# Altium schematic sheet MSXIV_DC-DC_Converter.SchDoc
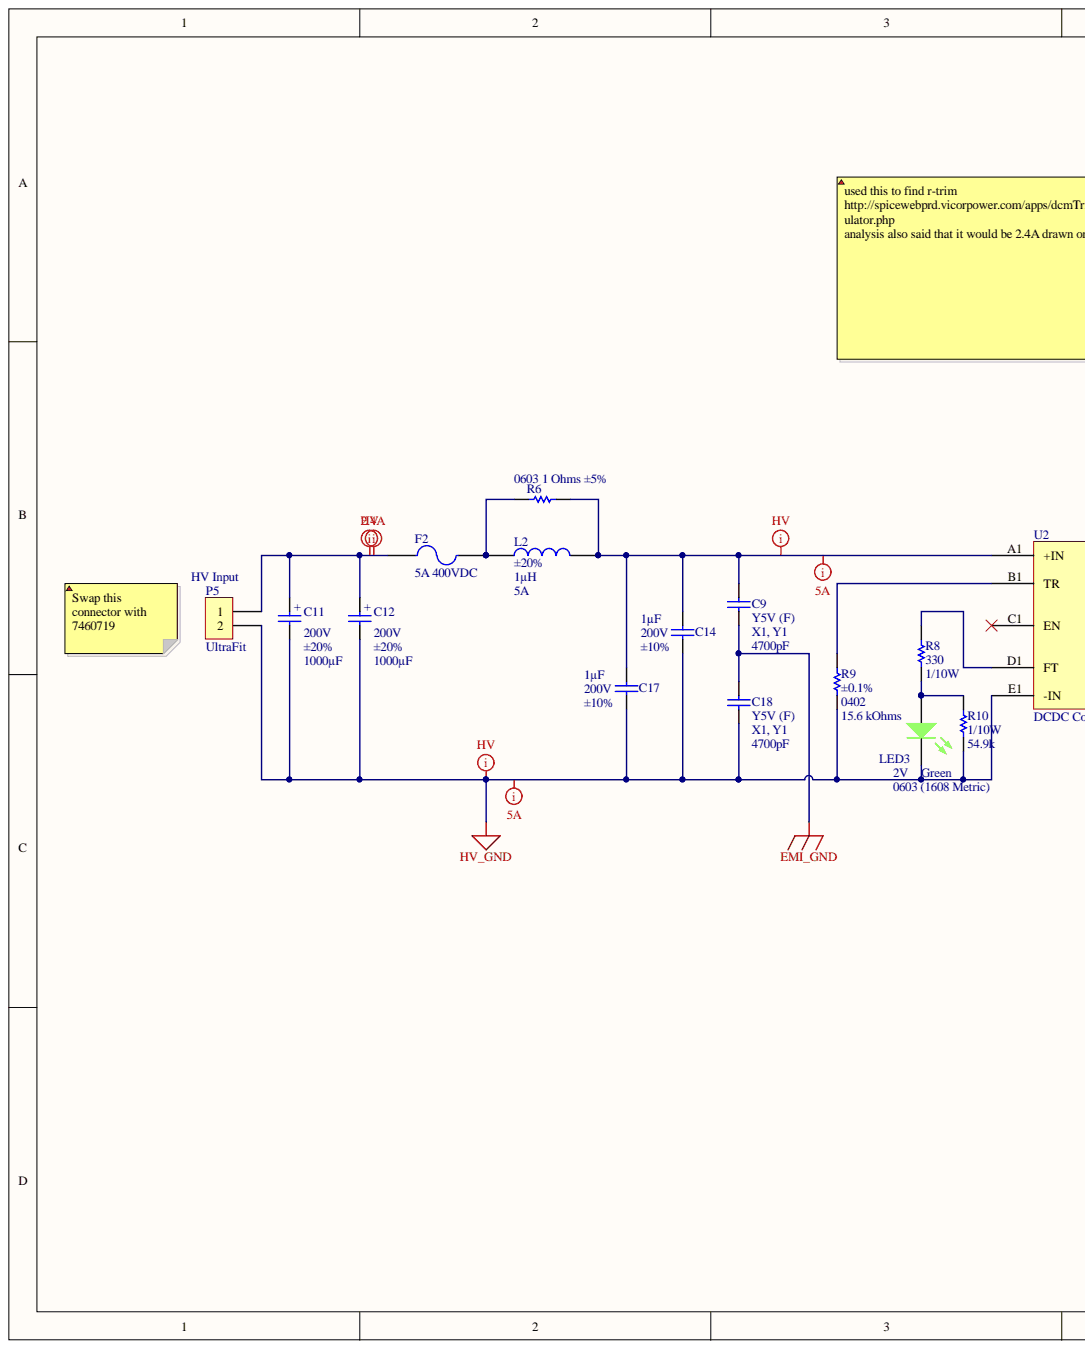
[diagram p0: MSXIV_DC-DC_Converter.SchDoc, left]
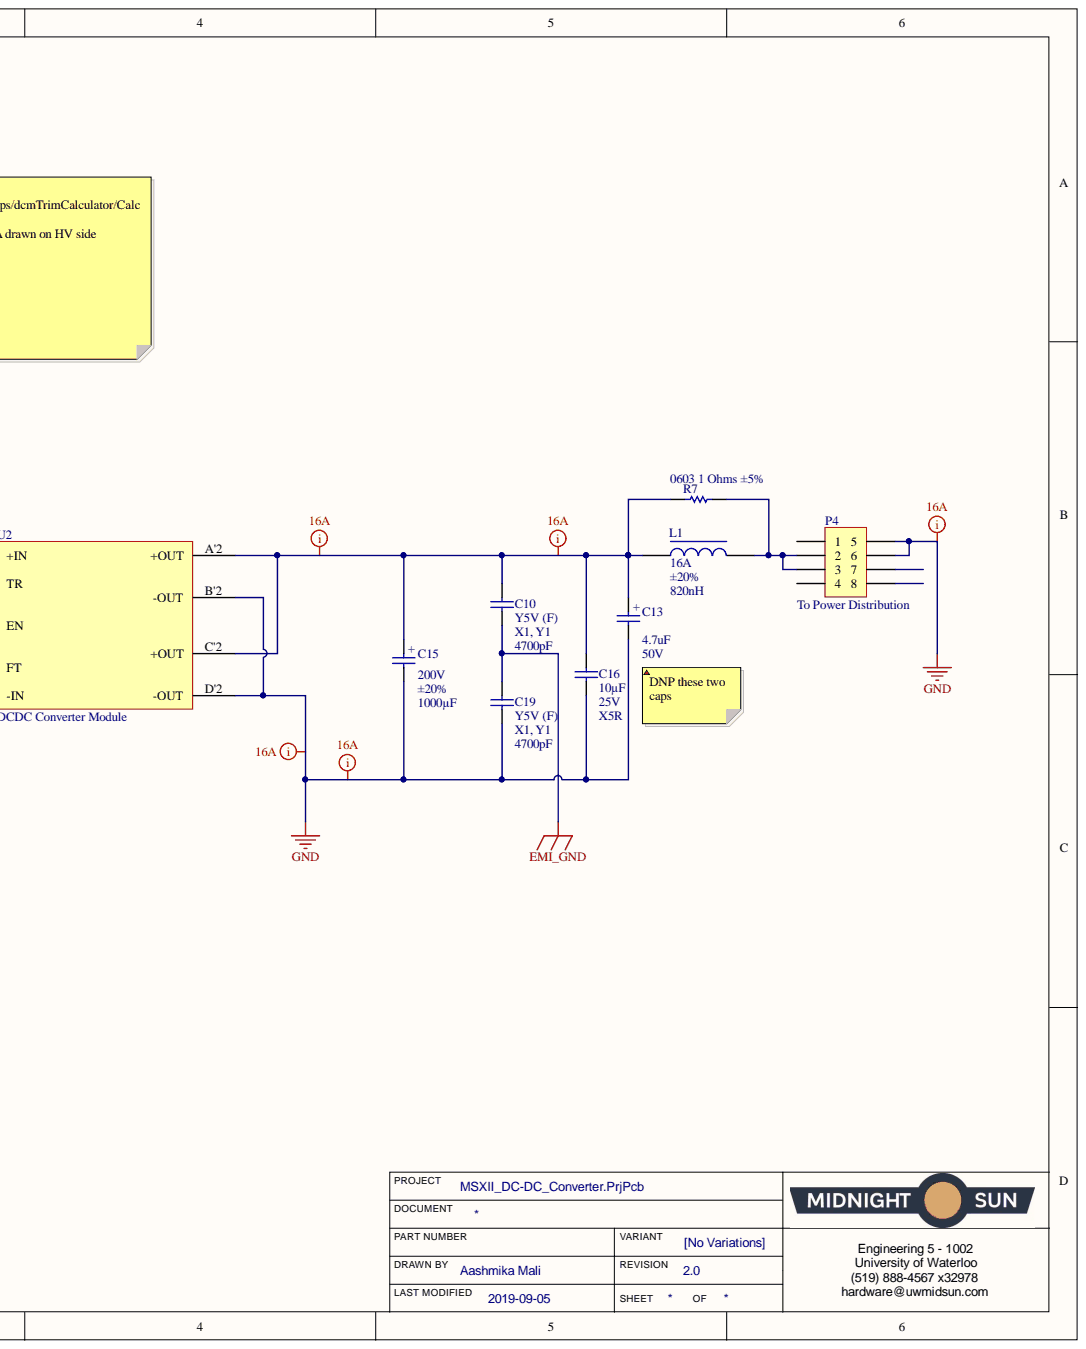
[diagram p1: MSXIV_DC-DC_Converter.SchDoc, right]

## Parts
C9  value 4700pF  Ratings: X1, Y1  Temperature Coefficient: Y5V (F)  [CAP 4700PF 400VAC Y5V RADIAL]  (hidden comment: CAP CER 4700PF 400VAC Y5V RADIAL)
C10  value 4700pF  Ratings: X1, Y1  Temperature Coefficient: Y5V (F)  [CAP 4700PF 400VAC Y5V RADIAL]  (hidden comment: CAP CER 4700PF 400VAC Y5V RADIAL)
C11  Capacitance: 1000µF  Tolerance: ±20%  Voltage - Rated: 200V  (hidden comment: CAP ALUM 1000UF 20% 200V SNAP)
C12  Capacitance: 1000µF  Tolerance: ±20%  Voltage - Rated: 200V  (hidden comment: CAP ALUM 1000UF 20% 200V SNAP)
C13  Capacitance: 4.7uF  Voltage Rating: 50V  [CAP ALUM 6.3MM RADIAL SMD]  (hidden comment: EEE-1HA4R7NP)
C14  Capacitance: 1µF  Tolerance: ±10%  Voltage - Rated: 200V  (hidden comment: CAP CER 1UF 200V X7R 2220)
C15  Capacitance: 1000µF  Tolerance: ±20%  Voltage - Rated: 200V  (hidden comment: CAP ALUM 1000UF 20% 200V SNAP)
C16  Capacitance: 10µF  Temperature Coefficient: X5R  Voltage: 25V  [CAP, 0603]  (hidden comment: CAP CER 10uF 25V 20% X5R 0603)
C17  Capacitance: 1µF  Tolerance: ±10%  Voltage - Rated: 200V  (hidden comment: CAP CER 1UF 200V X7R 2220)
C18  value 4700pF  Ratings: X1, Y1  Temperature Coefficient: Y5V (F)  [CAP 4700PF 400VAC Y5V RADIAL]  (hidden comment: CAP CER 4700PF 400VAC Y5V RADIAL)
C19  value 4700pF  Ratings: X1, Y1  Temperature Coefficient: Y5V (F)  [CAP 4700PF 400VAC Y5V RADIAL]  (hidden comment: CAP CER 4700PF 400VAC Y5V RADIAL)
F2  5A 400VDC  [FUSE 5A 400VDC RADIAL]
L1  Current Rating (Amps): 16A  Tolerance: ±20%  (hidden comment: IND 820NH 16A 6 MOHM SMD)
L2  Current Rating (Amps): 5A  Inductance: 1µH  Tolerance: ±20%  (hidden comment: IND 1UH 5A 12MOHM SMD)
LED3  Color: Green  Package / Case: 0603 (1608 Metric)  Supplier Device Package: 0603  Voltage - Forward (Vf) (Typ): 2V  [LED_0603]  (hidden comment: LED GREEN CLEAR 2V 0603)
P4  CONN 8POS ULTRA-FIT 0.138"  [CONN, 8POS ULTRA-FIT]  (value not drawn)
P5  Series: UltraFit  [CONN, 2POS ULTRA-FIT]  (hidden comment: CONN 2POS ULTRA-FIT 0.138")
R6  Resistance: 1 Ohms  Supplier Device Package: 0603  Tolerance: ±5%  (hidden comment: RES 1 OHM 5% 1/10W 0603)
R7  Resistance: 1 Ohms  Supplier Device Package: 0603  Tolerance: ±5%  (hidden comment: RES 1 OHM 5% 1/10W 0603)
R8  Power: 1/10W  [RES, 0603]  (hidden comment: RES 330 OHM 1% 1/10W 0603)
R9  Supplier Device Package: 0402  Tolerance: ±0.1%  (hidden comment: RES 15.6K OHM 0.1% 1/10W 0402)
R10  Power (Watts): 1/10W  Resistance (Ohms): 54.9k  [RES, 0603]  (hidden comment: RES 54.9K OHM 1% 1/10W 0603)
U2  Type: DCDC Converter Module  (hidden comment: DCDC VICOR CONVERTER 15V 240W - DCM3623TA5N17B4T70)

## Nets
EMI_GND: C9.2 C10.2 C18.1 C19.1
GND: C13.2 C15.2 C16.2 C19.2 P4.5 P4.6 U2.B'2(-OUT) U2.D'2(-OUT)
HV_GND: C11.2 C12.2 C14.2 C17.2 C18.2 LED3.2 P5.2 R9.1 R10.2 U2.E1(-IN)
NetC9_1: C9.1 C14.1 C17.1 L2.2 R6.2 U2.A1(+IN)
NetC10_1: C10.1 C13.1 C15.1 C16.1 L1.1 R7.1 U2.A'2(+OUT) U2.C'2(+OUT)
NetC11_1: C11.1 C12.1 F2.1 P5.1
NetF2_2: F2.2 L2.1 R6.1
NetL1_2: L1.2 P4.2 P4.3 R7.2
NetLED3_1: LED3.1 R8.2 R10.1
NetR8_1: R8.1 U2.D1(FT)
NetR9_2: R9.2 U2.B1(TR)

Not connected: P4 1 4 7 8; U2 C1(EN)

## Library data of the parts
C9 C10 C18 C19 = CAP CER 4700PF 400VAC Y5V RADIAL: 4700pF ±20% 400VAC Ceramic Capacitor Y5V (F) Radial, Disc; Manufacturer: KEMET; Manufacturer Part Number: C951U472MVVDBA7317; Applications: Safety; Category: Capacitors; Component Kind: Standard; ComponentLink1Description: https://search.kemet.com/component-edge/download/datasheet/C951U472MVVDBA7317.pdf; ComponentLink1URL: https://search.kemet.com/component-edge/download/datasheet/C951U472MVVDBA7317.pdf; Failure Rate: -; Features: -; Height - Seated (Max): -; Lead Spacing: 0.394" (10.00mm); Lead Style: Formed Leads - Kinked; Mounting Type: Through Hole; Operating Temperature: -40°C ~ 125°C; Package / Case: Radial, Disc; Packaging: Cut Tape (CT); Part Status: Active; Pricing 1: 1=1.12, 10=0.795, 100=0.5234, 500=0.38772 (CAD); RoHS: RoHS Compliant; Size / Dimension: 0.472" Dia (12.00mm); Stock 1: 9713; Supplier 1: Digi-Key; Supplier Part Number 1: 399-9517-1-ND; Thickness (Max): -; Tolerance: ±20%; Voltage - Rated: 400VAC; Library Name: Schematic Diagrams.SchLib
C11 C12 C15 = CAP ALUM 1000UF 20% 200V SNAP: CAP RADIAL ALUM 1000UF 20% 200V SNAP; Manufacturer: Cornell Dubilier Electronics (CDE); Manufacturer Part Number: SLPX102M200C4P3; ESR (Equivalent Series Resistance): 199mOhm @ 120Hz; Polarization: Polar; Component Kind: Standard; Mounting Type: Through Hole; Package / Case: Radial, Can - Snap-In; Supplier 1: Digi-Key; Supplier Part Number 1: 338-1557-ND; Library Name: Schematic Diagrams.SchLib
C13 = CAP ALUM 4.7UF 20% 50V SMD: 4.7µF 50V Aluminum Electrolytic Capacitors Radial, Can - SMD 2000 Hrs @ 85°C; Manufacturer 1: Panasonic; Description 1: CAPACITOR ALUM ELEC, 4.7UF, 50V, 20%, SMD; Life (Hours): 2000Hour; Ripple Current: 31mA; Tolerance: 20%; Case/Package: Radial; Component Kind: Standard; ComponentLink1Description: https://datasheet.ciiva.com/46374/aba0000ce2-46374373.pdf; ComponentLink1URL: https://datasheet.ciiva.com/46374/aba0000ce2-46374373.pdf; ComponentLink2Description: https://datasheet.ciiva.com/14600/70255710-14600343.pdf; ComponentLink2URL: https://datasheet.ciiva.com/14600/70255710-14600343.pdf; ComponentLink3Description: https://datasheet.ciiva.com/17536/aba0000ce2-17536647.pdf; ComponentLink3URL: https://datasheet.ciiva.com/17536/aba0000ce2-17536647.pdf; ComponentLink4Description: https://datasheet.ciiva.com/24027/aba0000ce2-40801-24027083.pdf; ComponentLink4URL: https://datasheet.ciiva.com/24027/aba0000ce2-40801-24027083.pdf; ComponentLink5Description: https://datasheet.ciiva.com/31414/aba0000ce2-31414684.pdf; ComponentLink5URL: https://datasheet.ciiva.com/31414/aba0000ce2-31414684.pdf; ComponentLink6Description: https://datasheet.ciiva.com/14606/70255710-14606079.pdf; ComponentLink6URL: https://datasheet.ciiva.com/14606/70255710-14606079.pdf; Diameter: 6.3mm; Height: 5.4mm; Height - Seated (Max): 0.213inch; Lead Free: Lead Free; Length: 5.5mm; Manufacturer Part Number 1: EEE-1HA4R7NP; Max Operating Temperature: 85°C; Min Operating Temperature: -40°C; Mount: Surface Mount,PCB; Package Quantity: 1; Packaging: Tape and Reel; Pricing 1: 1=0.43, 10=0.302, 100=0.1986, 500=0.1471 (USD); Radiation Hardening: No; REACH SVHC: No SVHC; Ripple Current (AC): 31mA; Stock 1: 19771; Supplier 1: Digi-Key; Supplier Part Number 1: PCE4304CT-ND; Termination: SMD/SMT; Voltage Rating (DC): 50V; Width: 650um; Library Name: Schematic Diagrams.SchLib
C14 C17 = CAP CER 1UF 200V X7R 2220: 1µF ±10% 200V Ceramic Capacitor X7R 2220 (5750 Metric); Manufacturer: Murata Electronics; Manufacturer Part Number: GRM55DR72D105KW01L; Component Kind: Standard; Mounting Type: Surface Mount, MLCC; Package / Case: 2220 (5750 Metric); Size / Dimension: 0.224" L x 0.197" W (5.70mm x 5.00mm); Supplier 1: Digi-Key; Supplier Part Number 1: 490-14683-1-ND; Temperature Coefficient: X7R; Library Name: Schematic Diagrams.SchLib
C16 = CAP CER 10uF 25V 20% X5R 0603: 10µF ±20% 25V Ceramic Capacitor X5R 0603 (1608 Metric); Manufacturer 1: Murata Electronics North America; Manufacturer Part Number 1: GRM188R61E106MA73D; Component Kind: Standard; ComponentLink1Description: http://www.murata.com/~/media/webrenewal/support/library/catalog/products/capacitor/mlcc/c02e.ashx; ComponentLink1URL: http://www.murata.com/~/media/webrenewal/support/library/catalog/products/capacitor/mlcc/c02e.ashx; Height - Seated (Max): -; Lead Spacing: -; Lead Style: -; Mounting Type: Surface Mount, MLCC; Operating Temperature: -55°C ~ 85°C; Package / Case: 0603 (1608 Metric); Packaging: Cut Tape (CT); Part Status: Active; Ratings: -; Size / Dimension: 0.063" L x 0.031" W (1.60mm x 0.80mm); Supplier 1: Digi-Key; Supplier Part Number 1: 490-7202-1-ND; Thickness (Max): 0.031" (0.80mm); Tolerance: ±20%; Library Name: Schematic Diagrams.SchLib
F2 = FUSE 5A 125VAC/400VDC RADIAL: FUSE BOARD MNT 5A 125VAC/400VDC; Manufacturer: Eaton; Manufacturer Part Number: BK/PCE-5-R; Approvals: CSA, UL; Breaking Capacity @ Rated Voltage: 10kA AC, 4.2kA DC; Fuse Type: Board Mount (Cartridge Style Excluded); Response Time: Fast; Category: Circuit Protection; Color: -; Component Kind: Standard; ComponentLink1Description: http://www.cooperindustries.com/content/dam/public/bussmann/Electronics/Resources/product-datasheets/Bus_Elx_DS_2034_PC_Tron_Series.pdf; ComponentLink1URL: http://www.cooperindustries.com/content/dam/public/bussmann/Electronics/Resources/product-datasheets/Bus_Elx_DS_2034_PC_Tron_Series.pdf; Mounting Type: Through Hole; Operating Temperature: -; Package / Case: Radial, Box; Packaging: Bulk; Part Status: Active; Pricing 1: 1=3.06, 5=2.91, 10=2.765, 50=2.2306, 100=1.8911, 250=1.8426, 500=1.55166, 1000=1.38196, 5000=1.21224 (CAD); RoHS: RoHS Compliant; Size / Dimension: 0.300" L x 0.095" W x 0.170" H (7.62mm x 2.41mm x 4.32mm); Stock 1: 0; Supplier 1: Digi-Key; Supplier Part Number 1: 283-2770-ND; Library Name: Schematic Diagrams.SchLib
L1 = IND 820NH 16A 6 MOHM SMD: 820nH Shielded Molded Inductor 16A 6mOhm Max Nonstandard; Manufacturer: ECS Inc.; Manufacturer Part Number: ECS-MPIL0630-R82MC; Component Kind: Standard; DC Resistance (DCR): 6mOhm Max; Height - Seated (Max): 0.118" (3.00mm); Inductance: 820nH; Mounting Type: Surface Mount; Package / Case: Nonstandard; Shielding: Shielded; Size / Dimension: 0.291" L x 0.260" W (7.40mm x 6.60mm); Supplier 1: Digi-Key; Supplier Part Number 1: XC2399CT-ND; Library Name: Schematic Diagrams.SchLib
L2 = IND 1UH 5A 12MOHM SMD: 1µH Semi-Shielded Wirewound Inductor 5A 12mOhm Nonstandard; Manufacturer: Bourns Inc.; Manufacturer Part Number: SRN5040TA-1R0M; Current - Saturation: 7.5A; Component Kind: Standard; DC Resistance (DCR): 12mOhm; Mounting Type: Surface Mount; Package / Case: Nonstandard; Shielding: Semi-Shielded; Supplier 1: Digi-Key; Supplier Part Number 1: SRN5040TA-1R0MCT-ND; Library Name: Schematic Diagrams.SchLib
LED3 = LED GREEN CLEAR 2V 0603: Green 572nm LED Indication - Discrete 2V 0603 (1608 Metric); Manufacturer: Wurth Electronics Inc.; Manufacturer Part Number: 150060VS75000; Current - Test: 20mA; Lens Color: Colorless; Lens Style/Size: Rectangle with Flat Top, 1.10mm x 0.70mm; Millicandela Rating: 40mcd; Viewing Angle: 140°; Wavelength - Dominant: 570nm; Category: Optoelectronics; Component Kind: Standard; ComponentLink1Description: http://katalog.we-online.de/led/datasheet/150060VS75000.pdf; ComponentLink1URL: http://katalog.we-online.de/led/datasheet/150060VS75000.pdf; Features: -; Height (Max): 0.80mm; Lens Transparency: Clear; Mounting Type: Surface Mount; Packaging: Cut Tape (CT); Part Status: Active; Pricing 1: 1=0.21, 100=0.1617, 500=0.13964 (CAD); RoHS: RoHS Compliant; Size / Dimension: 1.60mm L x 0.80mm W; Stock 1: 9408; Supplier 1: Digi-Key; Supplier Part Number 1: 732-4980-1-ND; Wavelength - Peak: 572nm; Library Name: Schematic Diagrams.SchLib
P4 = CONN 8POS ULTRA-FIT 0.138": 8 Positions Header Connector 0.138" (3.50mm) Through Hole Tin; Manufacturer: Molex, LLC; Manufacturer Part Number: 1722991108; Contact Length - Post: 0.126" (3.20mm); Mated Stacking Heights: 26.99mm; Material Flammability Rating: UL94 V-0; Number of Positions: 8; Number of Positions Loaded: All; Row Spacing - Mating: 0.181" (4.60mm); Shrouding: Shrouded - 4 Wall; Style: Board to Cable/Wire; Voltage Rating: 400V; Applications: Automotive, General Purpose, Industrial, Lighting, Medical, Military, Telecommunications; Category: Connectors, Interconnects; Component Kind: Standard; ComponentLink1Description: http://www.molex.com/pdm_docs/sd/1722991108_sd.pdf; ComponentLink1URL: http://www.molex.com/pdm_docs/sd/1722991108_sd.pdf; Connector Type: Header; Contact Finish - Mating: Tin; Contact Finish - Post: Tin; Contact Finish Thickness - Mating: 60µin (1.52µm); Contact Finish Thickness - Post: 60µin (1.52µm); Contact Length - Mating: -; Contact Material: Copper Alloy; Contact Shape: Square; Contact Type: Male Pin; Current Rating: -; Fastening Type: Latch Holder; Features: Board Guide, Solder Retention; Ingress Protection: -; Insulation Color: Black; Insulation Height: 0.465" (11.80mm); Insulation Material: Liquid Crystal Polymer (LCP); Mounting Type: Through Hole; Number of Rows: 2; Operating Temperature: -40°C ~ 105°C; Overall Contact Length: -; Packaging: Tray; Part Status: Active; Pitch - Mating: 0.138" (3.50mm); Pricing 1: 1=2.12, 10=1.923, 50=1.7262, 100=1.6478, 250=1.49084, 500=1.37314, 1000=1.17697, 2500=1.0985, 5000=1.04358 (CAD); RoHS: RoHS Compliant; Stock 1: 60; Supplier 1: Digi-Key; Supplier Part Number 1: WM11779-ND; Termination: Solder; Library Name: Schematic Diagrams.SchLib
P5 = CONN 2POS ULTRA-FIT 0.138": 2 Positions Header, Shrouded Connector 0.138" (3.50mm) Through Hole Gold; Manufacturer 1: Molex, LLC; Manufacturer Part Number 1: 1722861302; Contact Length - Post: 0.126" (3.20mm); Mated Stacking Heights: 26.99mm; Material Flammability Rating: UL94 V-0; Number of Positions: 2; Number of Positions Loaded: All; Shrouding: Shrouded - 4 Wall; Style: Board to Board or Cable; Voltage: 400V; Component Kind: Standard; ComponentLink1Description: http://www.molex.com/pdm_docs/sd/1722861302_sd.pdf; ComponentLink1URL: http://www.molex.com/pdm_docs/sd/1722861302_sd.pdf; Connector Type: Header; Contact Finish - Mating: Gold; Contact Finish - Post: Tin; Contact Finish Thickness - Mating: 30µin (0.76µm); Contact Finish Thickness - Post: 60µin (1.52µm); Contact Length - Mating: -; Contact Material: Copper Alloy; Contact Shape: Square; Contact Type: Male Pin; Current Rating: -; Fastening Type: Latch Holder; Ingress Protection: -; Insulation Color: Black; Insulation Height: 0.465" (11.80mm); Insulation Material: Liquid Crystal Polymer (LCP); Mounting Type: Through Hole; Number of Rows: 1; Operating Temperature: -40°C ~ 120°C; Overall Contact Length: -; Packaging: Tray; Part Status: Active; Pitch - Mating: 0.138" (3.50mm); Row Spacing - Mating: -; Supplier 1: Digi-Key; Supplier Part Number 1: WM11673-ND; Termination: Kinked Pin, Solder; Library Name: Schematic Diagrams.SchLib
R6 R7 = RES 1 OHM 5% 1/10W 0603: RES SMD 1 OHM 5% 1/10W 0603; Manufacturer: Rohm Semiconductor; Manufacturer Part Number: KTR03EZPJ1R0; Detailed Description : 1 Ohms ±5% 0.1W, 1/10W Chip Resistor 0603 (1608 Metric) Automotive AEC-Q200, High Voltage Thick Film; Power (Watts): 0.1W, 1/10W; Component Kind: Standard; Digi-Key Part Number: RHM1.0AZCT-ND; Package / Case: 0603 (1608 Metric); Library Name: Schematic Diagrams.SchLib
R8 = RES 330 OHM 1% 1/10W 0603: 330 Ohms ±1% 0.1W, 1/10W Chip Resistor 0603 (1608 Metric) Automotive AEC-Q200, Moisture Resistant Thick Film; Manufacturer: TE Connectivity; Manufacturer Part Number: 1-2176339-9; Resistance: 330; Component Kind: Standard; Stock 1: 0; Supplier 1: Digi-Key; Supplier Part Number 1: A129682TR-ND; Library Name: Schematic Diagrams.SchLib
R9 = RES 15.6K OHM 0.1% 1/10W 0402: RES SMD 15.6K OHM 0.1% 1/10W 0402; Manufacturer: Vishay Dale; Manufacturer Part NumberManufacturer Part Number: TNPW040215K6BEED; Resistance: 15.6 kOhms; Component Kind: Standard; Supplier 1: Digi-Key; Supplier Part Number 1: 541-2079-1-ND; Library Name: Schematic Diagrams.SchLib
R10 = RES 54.9K OHM 1% 1/10W 0603: 54.9k Ohm ±1% 0.1W, 1/10W Chip Resistor 0603 (1608 Metric) Automotive AEC-Q200 Thick Film; Manufacturer: Panasonic Electronic Components; Manufacturer Part Number: ERJ-3EKF5492V; Temperature Coefficient: ±100ppm/°C; Category: Resistors; Component Kind: Standard; ComponentLink1Description: https://industrial.panasonic.com/cdbs/www-data/pdf/RDA0000/AOA0000C298.pdf; ComponentLink1URL: https://industrial.panasonic.com/cdbs/www-data/pdf/RDA0000/AOA0000C298.pdf; Composition: Thick Film; Failure Rate: -; Features: Automotive AEC-Q200; Height - Seated (Max): 0.022" (0.55mm); Operating Temperature: -55°C ~ 155°C; Package / Case: 0603 (1608 Metric); Packaging: Cut Tape (CT); Part Status: Active; Pricing 1: 1=0.16, 10=0.031, 100=0.0127, 1000=0.00567, 2500=0.00492 (CAD); RoHS: RoHS Compliant; Size / Dimension: 0.063" L x 0.031" W (1.60mm x 0.80mm); Stock 1: 74038; Supplier 1: Digi-Key; Supplier Device Package: 0603; Supplier Part Number 1: P54.9KHCT-ND; Tolerance: ±1%; Library Name: Schematic Diagrams.SchLib
U2 = DCDC VICOR CONVERTER 15V 240W - DCM3623TA5N17B4T70: DC DC CONVERTER 15V 240W; Manufacturer 1: XP Power; Manufacturer Part Number 1: ISE1212A; Current - Output (Max): 84mA; Efficiency: 81%; Number of Outputs: 1; Power: 1W; Voltage - Input (Max): 13.2V; Voltage - Input (Min): 10.8V; Voltage - Isolation: 1.5kV (1500V); Voltage - Output 1: 12V; Component Kind: Standard; ComponentLink1Description: http://www.vicorpower.com/documents/datasheets/DCM3623xA5N17B4y7z_ds.pdf; ComponentLink1URL: http://www.vicorpower.com/documents/datasheets/DCM3623xA5N17B4y7z_ds.pdf; Mounting Type: Surface Mount; Operating Temperature: -40°C ~ 105°C; Package / Case: 8-SMD Module, 5 Leads; Packaging: Cut Tape (CT); Part Status: Active; Size / Dimension: 0.50" L x 0.44" W x 0.29" H (12.7mm x 11.2mm x 7.3mm); Supplier 1: Digi-Key; Supplier Part Number 1: 1470-2950-1-ND; Voltage - Output 2: -; Voltage - Output 3: -; Library Name: Schematic Diagrams.SchLib
